# Altium schematic sheet USB_and_DAC.SchDoc
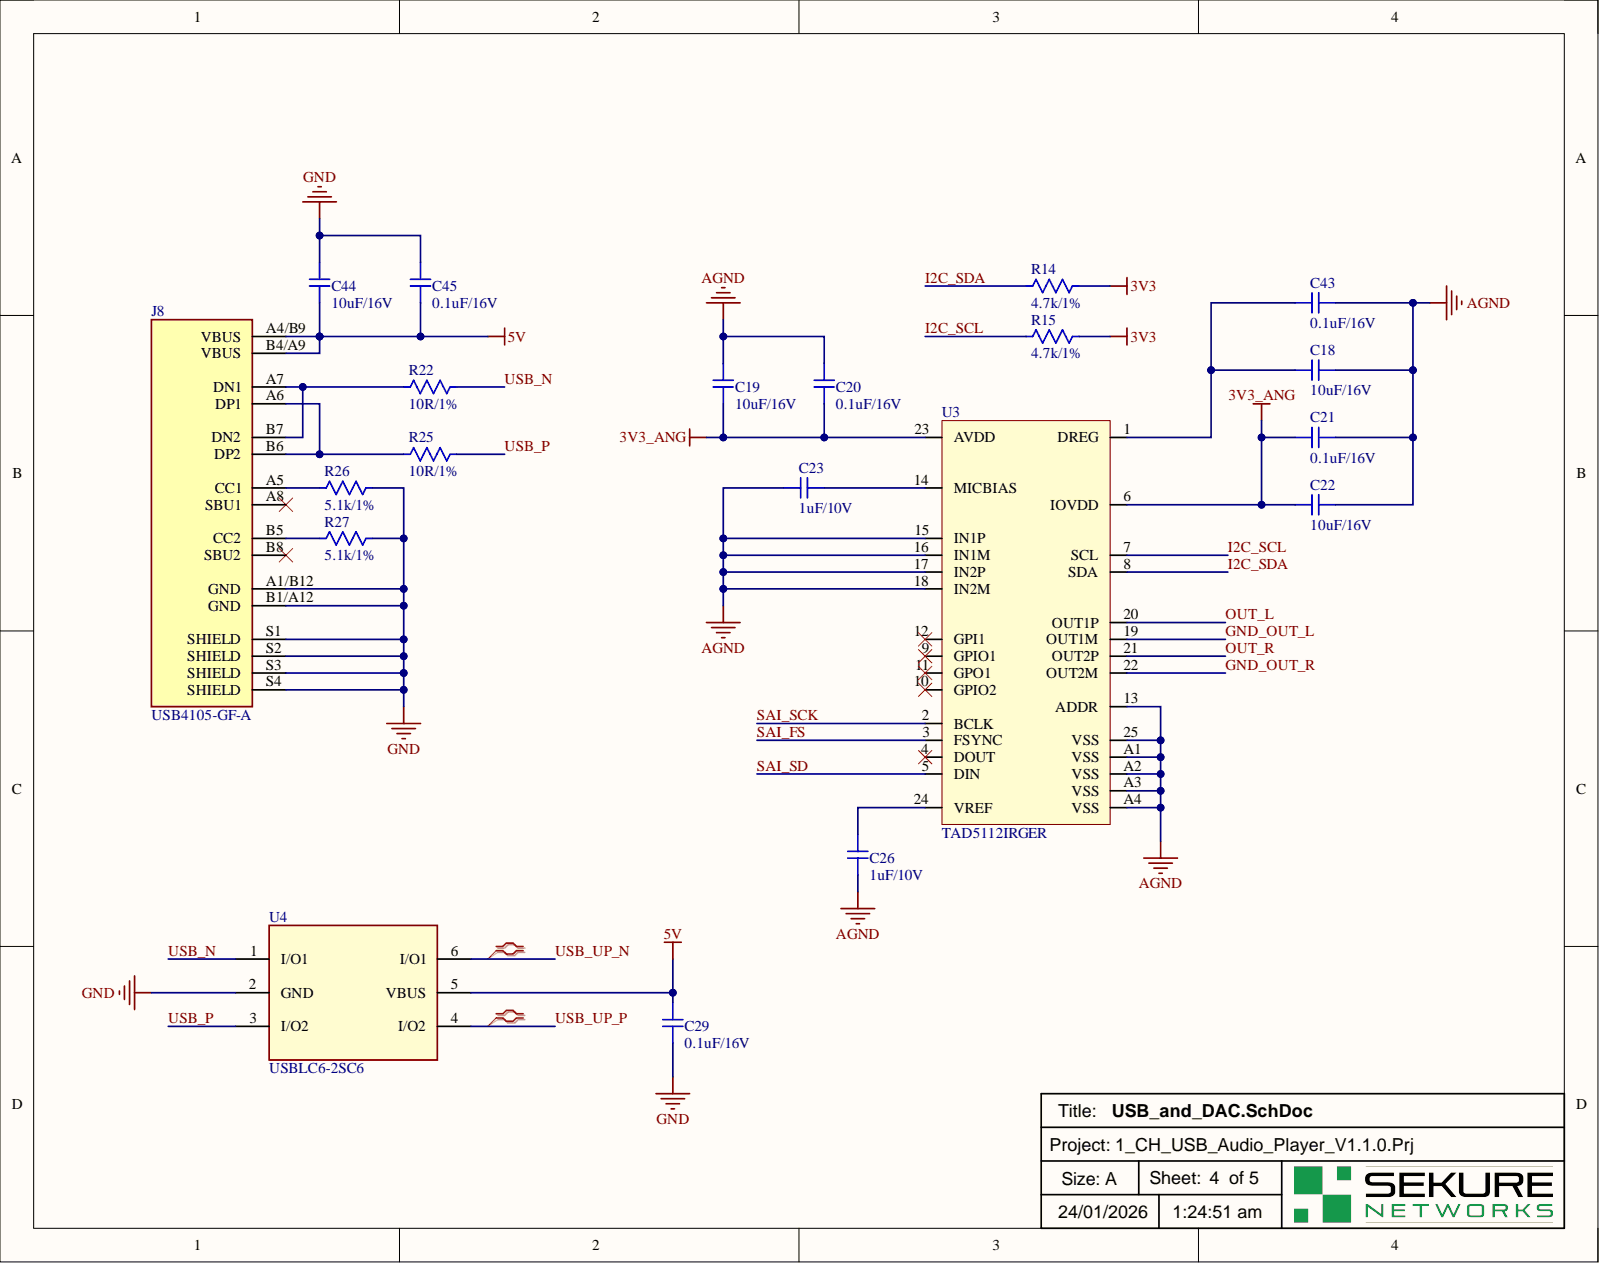
[diagram p0: USB_and_DAC.SchDoc]

## Parts
C18  value 10uF/16V  [Cap 0805]  (hidden comment: Ceramic Capacitor)
C19  value 10uF/16V  [Cap 0805]  (hidden comment: Ceramic Capacitor)
C20  value 0.1uF/16V  [CAP 0603]  (hidden comment: Ceramic Capacitor)
C21  value 0.1uF/16V  [CAP 0603]  (hidden comment: Ceramic Capacitor)
C22  value 10uF/16V  [Cap 0805]  (hidden comment: Ceramic Capacitor)
C23  value 1uF/10V  [CAP 0603]  (hidden comment: Ceramic Capacitor)
C26  value 1uF/10V  [CAP 0603]  (hidden comment: Ceramic Capacitor)
C29  value 0.1uF/16V  [CAP 0603]  (hidden comment: Ceramic Capacitor)
C43  value 0.1uF/16V  [CAP 0603]  (hidden comment: Ceramic Capacitor)
C44  value 10uF/16V  [Cap 0805]  (hidden comment: Ceramic Capacitor)
C45  value 0.1uF/16V  [CAP 0603]  (hidden comment: Ceramic Capacitor)
J8  value USB4105-GF-A  [USB4105-GF-A]  (hidden comment: Connector)
R14  value 4.7k/1%  [RES 0603]  (hidden comment: Resistor)
R15  value 4.7k/1%  [RES 0603]  (hidden comment: Resistor)
R22  value 10R/1%  [RES 0603]  (hidden comment: Resistor)
R25  value 10R/1%  [RES 0603]  (hidden comment: Resistor)
R26  value 5.1k/1%  [RES 0603]  (hidden comment: Resistor)
R27  value 5.1k/1%  [RES 0603]  (hidden comment: Resistor)
U3  value TAD5112IRGER  [24-VFQFN (4x4)]  (hidden comment: IC)
U4  value USBLC6-2SC6  [SOT23-6L]  (hidden comment: TVS Diode)

## Nets
3V3: R14.2 R15.2
3V3_ANG: C19.1 C20.1 C21.1 C22.1 U3.6(IOVDD) U3.23(AVDD)
5V: C29.1 C44.1 C45.1 J8.A4/B9(VBUS) J8.B4/A9(VBUS) U4.5(VBUS)
AGND: C18.1 C19.2 C20.2 C21.2 C22.2 C23.1 C26.2 C43.2 U3.13(ADDR) U3.15(IN1P) U3.16(IN1M) U3.17(IN2P) U3.18(IN2M) U3.25(VSS) U3.A1(VSS) U3.A2(VSS) U3.A3(VSS) U3.A4(VSS)
GND: C29.2 C44.2 C45.2 J8.A1/B12(GND) J8.B1/A12(GND) J8.S1(SHIELD) J8.S2(SHIELD) J8.S3(SHIELD) J8.S4(SHIELD) R26.1 R27.1 U4.2(GND)
GND_OUT_L: U3.19(OUT1M)
GND_OUT_R: U3.22(OUT2M)
I2C_SCL: R15.1 U3.7(SCL)
I2C_SDA: R14.1 U3.8(SDA)
OUT_L: U3.20(OUT1P)
OUT_R: U3.21(OUT2P)
SAI_FS: U3.3(FSYNC)
SAI_SCK: U3.2(BCLK)
SAI_SD: U3.5(DIN)
USB_N: R22.2 U4.1(I/O1)
USB_P: R25.2 U4.3(I/O2)
USB_UP_N: U4.6(I/O1)
USB_UP_P: U4.4(I/O2)
NetC18_2: C18.2 C43.1 U3.1(DREG)
NetC23_2: C23.2 U3.14(MICBIAS)
NetC26_1: C26.1 U3.24(VREF)
NetJ8_A5: J8.A5(CC1) R26.2
NetJ8_A6: J8.A6(DP1) J8.B6(DP2) R25.1
NetJ8_A7: J8.A7(DN1) J8.B7(DN2) R22.1
NetJ8_B5: J8.B5(CC2) R27.2

Not connected: J8 A8(SBU1) B8(SBU2); U3 4(DOUT) 9(GPIO1) 10(GPIO2) 11(GPO1) 12(GPI1)

## Library data of the parts
C18 C19 C22 C44 = CL21A106KOQNNNE: 16V 10uF X5R ±10% 0805 Multilayer Ceramic Capacitors MLCC - SMD/SMT ROHS; Manufacturer: Samsung Electro-Mechanics; Case Code (Imperial): 0805; Case Code (Metric): 2012; Case/Package: 0805; Category: Ceramic Capacitors; China RoHS: Compliant; Component Kind: Standard; ComponentLink1Description: https://datasheet.octopart.com:443/CL21A106KOQNNNE-Samsung-Electro-Mechanics-datasheet-136031799.pdf?src-supplier=IHS; ComponentLink1URL: https://datasheet.octopart.com:443/CL21A106KOQNNNE-Samsung-Electro-Mechanics-datasheet-136031799.pdf?src-supplier=IHS; ComponentLink2Description: https://datasheet.octopart.com/CL21A106KOQNNNE-Samsung-Electro-Mechanics-datasheet-8324245.pdf; ComponentLink2URL: https://datasheet.octopart.com/CL21A106KOQNNNE-Samsung-Electro-Mechanics-datasheet-8324245.pdf; ComponentLink3Description: https://datasheet.octopart.com/CL21A106KOQNNNE-Samsung-Electro-Mechanics-datasheet-97040617.pdf; ComponentLink3URL: https://datasheet.octopart.com/CL21A106KOQNNNE-Samsung-Electro-Mechanics-datasheet-97040617.pdf; ComponentLink4Description: https://datasheet.octopart.com:443/CL21A106KOQNNNE-Samsung-Electro-Mechanics-datasheet-130012977.pdf?src-supplier=Future+Electronics; ComponentLink4URL: https://datasheet.octopart.com:443/CL21A106KOQNNNE-Samsung-Electro-Mechanics-datasheet-130012977.pdf?src-supplier=Future+Electronics; ComponentLink5Description: https://datasheet.octopart.com:443/CL21A106KOQNNNE-Samsung-Electro-Mechanics-datasheet-82614846.pdf?src-supplier=Future+Electronics; ComponentLink5URL: https://datasheet.octopart.com:443/CL21A106KOQNNNE-Samsung-Electro-Mechanics-datasheet-82614846.pdf?src-supplier=Future+Electronics; ComponentLink6Description: https://datasheet.octopart.com:443/CL21A106KOQNNNE-Samsung-Electro-Mechanics-datasheet-81462122.pdf?src-supplier=Future+Electronics; ComponentLink6URL: https://datasheet.octopart.com:443/CL21A106KOQNNNE-Samsung-Electro-Mechanics-datasheet-81462122.pdf?src-supplier=Future+Electronics; ComponentLink7Description: https://datasheet.octopart.com:443/CL21A106KOQNNNE-Samsung-datasheet-48647542.pdf?src-supplier=Future+Electronics; ComponentLink7URL: https://datasheet.octopart.com:443/CL21A106KOQNNNE-Samsung-datasheet-48647542.pdf?src-supplier=Future+Electronics; ComponentLink8Description: https://datasheet.octopart.com:443/CL21A106KOQNNNE-Samsung-datasheet-26669228.pdf?src-supplier=Future+Electronics; ComponentLink8URL: https://datasheet.octopart.com:443/CL21A106KOQNNNE-Samsung-datasheet-26669228.pdf?src-supplier=Future+Electronics; ComponentLink9Description: https://datasheet.octopart.com:443/CL21A106KOQNNNE-Samsung-Electro-Mechanics-datasheet-149154152.pdf?src-supplier=Future+Electronics; ComponentLink9URL: https://datasheet.octopart.com:443/CL21A106KOQNNNE-Samsung-Electro-Mechanics-datasheet-149154152.pdf?src-supplier=Future+Electronics; ComponentLink10Description: https://datasheet.octopart.com:443/CL21A106KOQNNNE-Samsung-datasheet-22147434.pdf?src-supplier=TME; ComponentLink10URL: https://datasheet.octopart.com:443/CL21A106KOQNNNE-Samsung-datasheet-22147434.pdf?src-supplier=TME; Depth: 1.25mm; Dielectric: X5R; Dielectric Material: Ceramic; DigiKey: 1276-1096-1-ND; Height: 1.4mm; Lead Free: Lead Free; Length: 2mm; Library Name: Ceramic Capacitors.IntLib; Lifecycle Status: Production; Material: Ceramic; Max Operating Temperature: 85°C; Min Operating Temperature: -55°C; Mounting Technology: Surface Mount; Number of Terminals: 2; P/N: CL21A106KOQNNNE; Pricing: 1=0.08, 10=0.021, 50=0.02, 100=0.0192, 500=0.0144, 1000=0.0128 (USD); Radiation Hardening: No; REACH SVHC: Yes; RoHS: Compliant; Schedule B: 8532240020, 8532240020|8532240020|8532240020|8532240020|8532240020|8532240020|8532240020|8532240020|8532240020|8532240020|8532240020|8532240020|8532240020|8532240020|8532240020|8532240020|8532240020|8532240020|8532240020|8532240020|8532240020|8532240020|8532240020|85; Stock: 1352697; Supplier: DigiKey; Thickness: 1.397mm; Tolerance: 10%; Voltage: 16V; Voltage Rating: 16V; Voltage Rating (DC): 16V; Width: 1.25mm
C20 C21 C29 C43 C45 = CL10B104KO8NNNC: 16V 100nF X7R ±10% 0603 Multilayer Ceramic Capacitors MLCC - SMD/SMT ROHS; Manufacturer: Samsung Electro-Mechanics; Case Code (Imperial): 0603; Case Code (Metric): 1608; Case/Package: 0603; Category: Ceramic Capacitors; China RoHS: Compliant; Component Kind: Standard; ComponentLink1Description: https://datasheet.octopart.com:443/CL10B104KO8NNNC-Samsung-Electro-Mechanics-datasheet-136482305.pdf?src-supplier=IHS; ComponentLink1URL: https://datasheet.octopart.com:443/CL10B104KO8NNNC-Samsung-Electro-Mechanics-datasheet-136482305.pdf?src-supplier=IHS; ComponentLink2Description: https://datasheet.octopart.com/CL10B104KO8NNNC-Samsung-Electro-Mechanics-datasheet-8324245.pdf; ComponentLink2URL: https://datasheet.octopart.com/CL10B104KO8NNNC-Samsung-Electro-Mechanics-datasheet-8324245.pdf; ComponentLink3Description: https://datasheet.octopart.com/CL10B104KO8NNNC-Samsung-Electro-Mechanics-datasheet-97040617.pdf; ComponentLink3URL: https://datasheet.octopart.com/CL10B104KO8NNNC-Samsung-Electro-Mechanics-datasheet-97040617.pdf; ComponentLink4Description: https://datasheet.octopart.com:443/CL10B104KO8NNNC-Samsung-Electro-Mechanics-datasheet-116890973.pdf?src-supplier=Future+Electronics; ComponentLink4URL: https://datasheet.octopart.com:443/CL10B104KO8NNNC-Samsung-Electro-Mechanics-datasheet-116890973.pdf?src-supplier=Future+Electronics; ComponentLink5Description: https://datasheet.octopart.com:443/CL10B104KO8NNNC-Samsung-Electro-Mechanics-datasheet-81461844.pdf?src-supplier=Future+Electronics; ComponentLink5URL: https://datasheet.octopart.com:443/CL10B104KO8NNNC-Samsung-Electro-Mechanics-datasheet-81461844.pdf?src-supplier=Future+Electronics; ComponentLink6Description: https://datasheet.octopart.com:443/CL10B104KO8NNNC-Samsung-datasheet-42770384.pdf?src-supplier=Future+Electronics; ComponentLink6URL: https://datasheet.octopart.com:443/CL10B104KO8NNNC-Samsung-datasheet-42770384.pdf?src-supplier=Future+Electronics; ComponentLink7Description: https://datasheet.octopart.com:443/CL10B104KO8NNNC-Samsung-datasheet-26589157.pdf?src-supplier=Future+Electronics; ComponentLink7URL: https://datasheet.octopart.com:443/CL10B104KO8NNNC-Samsung-datasheet-26589157.pdf?src-supplier=Future+Electronics; ComponentLink8Description: https://datasheet.octopart.com:443/CL10B104KO8NNNC-Samsung-Electro-Mechanics-datasheet-149136789.pdf?src-supplier=Future+Electronics; ComponentLink8URL: https://datasheet.octopart.com:443/CL10B104KO8NNNC-Samsung-Electro-Mechanics-datasheet-149136789.pdf?src-supplier=Future+Electronics; ComponentLink9Description: https://datasheet.octopart.com:443/CL10B104KO8NNNC-Samsung-datasheet-8326407.pdf?src-supplier=TME; ComponentLink9URL: https://datasheet.octopart.com:443/CL10B104KO8NNNC-Samsung-datasheet-8326407.pdf?src-supplier=TME; ComponentLink10Description: https://datasheet.octopart.com:443/CL10B104KO8NNNC-Samsung-Electro-Mechanics-datasheet-11890603.pdf?src-supplier=Arrow+Electronics; ComponentLink10URL: https://datasheet.octopart.com:443/CL10B104KO8NNNC-Samsung-Electro-Mechanics-datasheet-11890603.pdf?src-supplier=Arrow+Electronics; Contact Plating: Tin; Depth: 800µm; Dielectric: X7R; Dielectric Material: Ceramic; DigiKey: 1276-1005-1-ND; Height: 800µm; Lead Free: Lead Free; Length: 1.6mm; Library Name: Ceramic Capacitors.IntLib; Lifecycle Status: Production; Material: Ceramic; Max Operating Temperature: 125°C; Min Operating Temperature: -55°C; Mounting Technology: Surface Mount; Number of Terminals: 2; P/N: CL10B104KO8NNNC; Pricing: 1=0.1, 10=0.026, 50=0.0172, 100=0.0146, 500=0.0103, 1000=0.00899 (USD); Radiation Hardening: No; REACH SVHC: Yes; RoHS: Compliant; Schedule B: 8532240020, 8532240020|8532240020, 8532240020|8532240020|8532240020, 8532240020|8532240020|8532240020|8532240020; Stock: 2399591; Supplier: DigiKey; Thickness: 889µm; Tolerance: 10%; Voltage: 16V; Voltage Rating: 16V; Voltage Rating (DC): 16V; Width: 800µm
C23 C26 = CL10B105KP8NNNC: Multilayer Ceramic Capacitor, 1 uF, 10 V, ± 10%, X7R, 0603 [1608 Metric]; Manufacturer: Samsung; Material: 4241ConductorMaterial; Voltage Rating: 10V; Case Code (Imperial): 0603; Case Code (Metric): 1608; Case/Package: 0603; Category: Ceramic Capacitors; Component Kind: Standard; Construction: Flat; Depth: 0.8mm; Dielectric: X7R; DigiKey: 1276-1946-1-ND; Height: 0.8mm; Lead Free: Lead Free; Length: 1.6mm; Library Name: Ceramic Capacitors.IntLib; Max Operating Temperature: 125°C; Min Operating Temperature: -55°C; Mounting Technology: Surface Mount; P/N: CL10B105KP8NNNC; Package Quantity: 1; Packaging: Tape and Reel; Pricing: 1=0.1, 10=0.026, 50=0.0146, 100=0.0114, 500=0.00858, 1000=0.00674 (USD); Radiation Hardening: No; RoHS: Yes; RoHS Compliant: Yes; Series: CL; Stock: 7012743; Supplier: Digikey; Thickness: 0.035inch; Tolerance: 10%; Voltage Rating (DC): 10V; Width: 0.031inch
J8 = USB4105-GF-A: Conn USB 2.0 Type C RCP 24 POS 0.3mm/0.5mm Solder RA SMD 24 Terminal 1 Port T/R; Manufacturer: Global Connector Technology; Gender: Receptacle; Mating Cycles: 10000; Number of Contacts: 16NUMBER; Number of Ports: 1; Shielding: Shielded; USB Standard: 6108USBStandard; Voltage Rating: 20V; Category: USB Connectors; Component Kind: Standard; ComponentLink1Description: https://gct.co/files/drawings/usb4105.pdf?src-supplier=Digikey; ComponentLink1URL: https://gct.co/files/drawings/usb4105.pdf?src-supplier=Digikey; Connector Type: USB; Contact Material: 153,157ConductorMaterial; Contact Plating: Gold; DigiKey: 2073-USB4105-GF-ACT-ND; Flammability Rating: UL94 V-0; Height: 3.46mm; Insulation Color: Black; Library Name: Connectors.IntLib; Max Operating Temperature: 85°C; Min Operating Temperature: -40°C; Mounting Technology: Surface Mount,Through Hole,Horizontal; Orientation: 5496OrientationType; P/N: USB4105-GF-A; Pins: 16NUMBER; Pricing: 1=0.78, 10=0.659, 25=0.6172, 50=0.5878, 100=0.5597, 250=0.5246 (USD); RoHS: No; Series: USB4105; Stock: 115444; Supplier: Digikey; Termination: Solder
R14 R15 = ERJ-3EKF4701V: RES SMD, 4.7K 0603, 0.1W, 1%; Manufacturer: Panasonic; Max Power Dissipation: 100mW; Resistance: 4.7kR; Temperature Coefficient: 100ppm/°C; Voltage Rating: 75V; Case Code (Imperial): 0603; Case Code (Metric): 1608; Case/Package: 0603; Component Kind: Standard; ComponentLink1Description: https://datasheet.ciiva.com/16449/aoa0000ce2-16449479.pdf?src-supplier=Future+Electronics; ComponentLink1URL: https://datasheet.ciiva.com/16449/aoa0000ce2-16449479.pdf?src-supplier=Future+Electronics; ComponentLink2Description: https://datasheet.ciiva.com/16511/aoa0000ce2-16511783.pdf?src-supplier=Avnet+Asia; ComponentLink2URL: https://datasheet.ciiva.com/16511/aoa0000ce2-16511783.pdf?src-supplier=Avnet+Asia; ComponentLink3Description: https://datasheet.ciiva.com/9195/aoa0000ce2-9195740.pdf?src-supplier=Avnet; ComponentLink3URL: https://datasheet.ciiva.com/9195/aoa0000ce2-9195740.pdf?src-supplier=Avnet; ComponentLink4Description: https://datasheet.ciiva.com/16758/70260748-16758189.pdf?src-supplier=Allied+Electronics; ComponentLink4URL: https://datasheet.ciiva.com/16758/70260748-16758189.pdf?src-supplier=Allied+Electronics; ComponentLink5Description: https://datasheet.ciiva.com/24160/aoa0000ce2-24160445.pdf?src-supplier=Mouser; ComponentLink5URL: https://datasheet.ciiva.com/24160/aoa0000ce2-24160445.pdf?src-supplier=Mouser; ComponentLink6Description: https://datasheet.ciiva.com/46372/1678782-46372717.pdf?src-supplier=Element14; ComponentLink6URL: https://datasheet.ciiva.com/46372/1678782-46372717.pdf?src-supplier=Element14; ComponentLink7Description: https://datasheet.ciiva.com/17213/aoa0000ce2-17213182.pdf?src-supplier=Avnet+Europe; ComponentLink7URL: https://datasheet.ciiva.com/17213/aoa0000ce2-17213182.pdf?src-supplier=Avnet+Europe; ComponentLink8Description: https://datasheet.ciiva.com/7830/426256-7830985.pdf?src-supplier=Element14; ComponentLink8URL: https://datasheet.ciiva.com/7830/426256-7830985.pdf?src-supplier=Element14; ComponentLink9Description: https://datasheet.ciiva.com/46372/1678782-46372716.pdf?src-supplier=Element14; ComponentLink9URL: https://datasheet.ciiva.com/46372/1678782-46372716.pdf?src-supplier=Element14; ComponentLink10Description: https://datasheet.ciiva.com/7830/aoa0000ce2-7830735.pdf?src-supplier=Element14; ComponentLink10URL: https://datasheet.ciiva.com/7830/aoa0000ce2-7830735.pdf?src-supplier=Element14; Composition: Thick Film; Contact Plating: Tin; Depth: 0.8mm; DigiKey: P4.70KHDKR-ND; Features: Automotive AEC-Q200; Height: 0.022inch; Lead Free: Lead Free; Length: 1.6mm; Library Name: Resistors.IntLib; Max Operating Temperature: 155°C; Military Standard: Not; Min Operating Temperature: -55°C; Mount: Surface Mount; Number of Pins: 2; Number of Terminations: 2; P/N: ERJ-3EKF4701V; Package Quantity: 1; Packaging: Tape and Reel; Power Rating: 100mW; Pricing 1: 1=0.10000, 10=0.05700, 100=0.02340, 1000=0.01049, 2500=0.00911 (USD); Radiation Hardening: No; REACH SVHC: No SVHC; RoHS: Yes; Stock 1: 588466; Supplier 1: Digi-Key; Termination: SMD/SMT; Tolerance: 1%; Voltage Rating (DC): 75V; Weight: 7.1E-05oz; Width: 0.031inch
R22 R25 = ERJ-U03F10R0V: SMD Chip Resistor, 0603 [1608 Metric], 10 ohm, ERJU03 Series, 75 V, Thick Film, 100 mW; Manufacturer: Panasonic; Current Rating: 1A; Power Dissipation: 100mW; Temperature Coefficient: 100ppm/°C; Tolerance: 1%; Voltage Rating: 75V; Case Code (Imperial): 0603; Case Code (Metric): 1608; Case/Package: 0603; Category: Chip SMD Resistors; Component Kind: Standard; ComponentLink1Description: https://datasheet.octopart.com:443/ERJ-U03F10R0V-Panasonic-datasheet-85390793.pdf?src-supplier=Panasonic+SCT; ComponentLink1URL: https://datasheet.octopart.com:443/ERJ-U03F10R0V-Panasonic-datasheet-85390793.pdf?src-supplier=Panasonic+SCT; ComponentLink2Description: https://datasheet.octopart.com:443/ERJ-U03F10R0V-Panasonic-datasheet-61627662.pdf?src-supplier=Farnell; ComponentLink2URL: https://datasheet.octopart.com:443/ERJ-U03F10R0V-Panasonic-datasheet-61627662.pdf?src-supplier=Farnell; ComponentLink3Description: https://datasheet.octopart.com:443/ERJ-U03F10R0V-Panasonic-datasheet-159616995.pdf?src-supplier=Newark; ComponentLink3URL: https://datasheet.octopart.com:443/ERJ-U03F10R0V-Panasonic-datasheet-159616995.pdf?src-supplier=Newark; ComponentLink4Description: https://datasheet.octopart.com:443/ERJ-U03F10R0V-Panasonic-datasheet-11808117.pdf?src-supplier=Newark; ComponentLink4URL: https://datasheet.octopart.com:443/ERJ-U03F10R0V-Panasonic-datasheet-11808117.pdf?src-supplier=Newark; ComponentLink5Description: https://datasheet.octopart.com:443/ERJ-U03F10R0V-Panasonic-datasheet-33006993.pdf?src-supplier=RS+(Formerly+Allied+Electronics); ComponentLink5URL: https://datasheet.octopart.com:443/ERJ-U03F10R0V-Panasonic-datasheet-33006993.pdf?src-supplier=RS+(Formerly+Allied+Electronics); ComponentLink6Description: https://datasheet.octopart.com:443/ERJ-U03F10R0V-Panasonic-datasheet-184398019.pdf?src-supplier=Master+Electronics; ComponentLink6URL: https://datasheet.octopart.com:443/ERJ-U03F10R0V-Panasonic-datasheet-184398019.pdf?src-supplier=Master+Electronics; ComponentLink7Description: https://datasheet.octopart.com:443/ERJU03F10R0V-Panasonic-datasheet-164871545.pdf?src-supplier=Burklin+Elektronik; ComponentLink7URL: https://datasheet.octopart.com:443/ERJU03F10R0V-Panasonic-datasheet-164871545.pdf?src-supplier=Burklin+Elektronik; ComponentLink8Description: https://datasheet.octopart.com/ERJ-U03F10R0V-Panasonic-datasheet-78375410.pdf; ComponentLink8URL: https://datasheet.octopart.com/ERJ-U03F10R0V-Panasonic-datasheet-78375410.pdf; Composition: Thick Film; Contact Plating: Tin; Depth: 800µm; DigiKey: 10-ERJ-U03F10R0VCT-ND; Height: 450µm; Length: 1.6mm; Library Name: Resistors.IntLib; Lifecycle Status: Production; Max Operating Temperature: 155°C; Min Operating Temperature: -55°C; Mounting Technology: Surface Mount; Number of Terminals: 2; P/N: ERJ-U03F10R0V; Packaging: Cut Tape; Power Rating: 100mW; Pricing: 1=0.14, 10=0.066, 25=0.0512, 50=0.043, 100=0.0364, 250=0.0298, 500=0.02602, 1000=0.02304 (USD); REACH SVHC: Yes; RoHS: Compliant; Stock: 7385; Supplier: DigiKey; Termination: SMD/SMT; Voltage Rating (DC): 75V; Weight: 2.012816mg; Width: 800µm; Working Voltage: 75V
R26 R27 = ERJ-3EKF5101V: Res Thick Film 0603 5.1K Ohm 1% 1/10W ±100ppm/°C Molded SMD Punched Carrier T/R; Manufacturer: Panasonic; Max Power Dissipation: 100mW; Resistance: 5.1kR; Voltage Rating: 75V; Case Code (Imperial): 0603; Case Code (Metric): 1608; Case/Package: 0603; Category: Chip SMD Resistors; Component Kind: Standard; ComponentLink1Description: https://datasheet.ciiva.com/5860/426256-5860664.pdf?src-supplier=Farnell; ComponentLink1URL: https://datasheet.ciiva.com/5860/426256-5860664.pdf?src-supplier=Farnell; ComponentLink2Description: https://datasheet.ciiva.com/28547/1678782-28547194.pdf?src-supplier=Element14; ComponentLink2URL: https://datasheet.ciiva.com/28547/1678782-28547194.pdf?src-supplier=Element14; ComponentLink3Description: https://datasheet.ciiva.com/28686/1678782-28686921.pdf?src-supplier=Element14; ComponentLink3URL: https://datasheet.ciiva.com/28686/1678782-28686921.pdf?src-supplier=Element14; ComponentLink4Description: https://datasheet.ciiva.com/16785/70260748-16785996.pdf?src-supplier=Allied+Electronics; ComponentLink4URL: https://datasheet.ciiva.com/16785/70260748-16785996.pdf?src-supplier=Allied+Electronics; ComponentLink5Description: https://datasheet.ciiva.com/16440/aoa0000ce3-16440166.pdf?src-supplier=Future+Electronics; ComponentLink5URL: https://datasheet.ciiva.com/16440/aoa0000ce3-16440166.pdf?src-supplier=Future+Electronics; ComponentLink6Description: https://datasheet.ciiva.com/16523/aoa0000ce2-16523461.pdf?src-supplier=Avnet+Asia; ComponentLink6URL: https://datasheet.ciiva.com/16523/aoa0000ce2-16523461.pdf?src-supplier=Avnet+Asia; Construction: Rectangular; Contact Plating: Tin; Depth: 0.8mm; DigiKey: P5.10KHCT-ND; Features: Automotive AEC-Q200; Height: 0.022inch; Lead Free: Lead Free; Length: 1.6mm; Library Name: Resistors.IntLib; Max Operating Temperature: 155°C; Military Standard: Not; Min Operating Temperature: -55°C; Mount: Surface Mount; Number of Terminations: 2; P/N: ERJ-3EKF5101V; Package Quantity: 1; Packaging: Tape and Reel; Power Rating: 100mW; Pricing 1: 1=0.10000, 10=0.04200, 100=0.01700, 1000=0.00762, 2500=0.00661 (USD); Radiation Hardening: No; REACH SVHC: No SVHC; Resistance Tolerance: 1%; Resistor Type: Thick Film; RoHS: Yes; RoHS Compliant: Yes; Series: ERJ; Size Code: 0603; Stock 1: 330; Supplier 1: Digi-Key; Temperature Coefficient: 100ppm/°C; Termination: SMD/SMT; Tolerance: 1%; Voltage Rating (DC): 75V; Weight: 7.1E-05oz; Width: 0.8mm
U3 = TAD5112IRGER: LOW-POWER STEREO AUDIO DAC WITH; Manufacturer: Texas Instruments; Component Kind: Standard; Digikey: 296-TAD5112IRGERTR-ND; Library Name: IC's.SchLib; P/N: TAD5112IRGER
U4 = USBLC6-2SC6: Trans Voltage Suppressor Diode, 5V V(RWM), Unidirectional, 1 Element, Silicon; Manufacturer: STMicroelectronics; Additional Feature: HIGH RELIABILITY; Clamping Voltage-Max: 17V; Min Breakdown Voltage: 6V; Number of Elements: 1; Package Body Material: Plastic; Qualification Status: Not Qualified; Reference Standard: IEC-61000-4-2; Category: TVS Diodes; Component Kind: Standard; ComponentLink1Description: https://datasheet.ciiva.com:443/pdfs/VipMasterIC/IC/SGST/SGSTS30336/SGSTS30336-1.pdf?src-supplier=IHS+Markit; ComponentLink1URL: https://datasheet.ciiva.com:443/pdfs/VipMasterIC/IC/SGST/SGSTS30336/SGSTS30336-1.pdf?src-supplier=IHS+Markit; ComponentLink2Description: https://datasheet.ciiva.com/1268/1690354-1268384.pdf?src-supplier=Element14; ComponentLink2URL: https://datasheet.ciiva.com/1268/1690354-1268384.pdf?src-supplier=Element14; ComponentLink3Description: https://datasheet.ciiva.com/4508/cd00050750-113117-4508433.pdf?src-supplier=Mouser; ComponentLink3URL: https://datasheet.ciiva.com/4508/cd00050750-113117-4508433.pdf?src-supplier=Mouser; ComponentLink4Description: https://datasheet.ciiva.com/15143/getdatasheetpartid-148465-15143789.pdf?src-supplier=Verical; ComponentLink4URL: https://datasheet.ciiva.com/15143/getdatasheetpartid-148465-15143789.pdf?src-supplier=Verical; ComponentLink5Description: https://datasheet.ciiva.com/16200/cd00050750-16200026.pdf?src-supplier=Future+Electronics; ComponentLink5URL: https://datasheet.ciiva.com/16200/cd00050750-16200026.pdf?src-supplier=Future+Electronics; ComponentLink6Description: https://datasheet.ciiva.com/41444/001184109-da-01-en-ic-esd-protecti-usblc6-2sc6-sot-23-6-stm-41444156.pdf?src-supplier=Conrad; ComponentLink6URL: https://datasheet.ciiva.com/41444/001184109-da-01-en-ic-esd-protecti-usblc6-2sc6-sot-23-6-stm-41444156.pdf?src-supplier=Conrad; DigiKey: 497-5235-1-ND; Diode Element Material: SILICON; Diode Type: TRANS VOLTAGE SUPPRESSOR; JESD-30 Code: R-PDSO-G6; JESD-609 Code: e3; Library Name: Diode.SchLib; Max Operating Temperature: 125°C; Min Operating Temperature: -40°C; Moisture Sensitivity Level: 1; P/N: USBLC6-2SC6; Package Shape: Rectangular; Package Style: SMALL OUTLINEMeter; Peak Reflow Temperature (Cel): 260°C; Pins: 6; Polarity: Unidirectional; Pricing: 1=0.35, 10=0.273, 100=0.1636, 500=0.1515, 1000=0.10302 (USD); Reach SVHC Compliant: Yes; Rep Pk Reverse Voltage-Max: 5V; RoHS: Yes; RoHS Compliant: Yes; Stock: 102967; Supplier: Digikey; Surface Mount: Yes; Technology: AVALANCHE; Terminal Finish: Tin; Terminal Form: Gull Wing; Terminal Position: DUAL
